# Altium schematic sheet Microcontroller.SchDoc(Microcontroller)
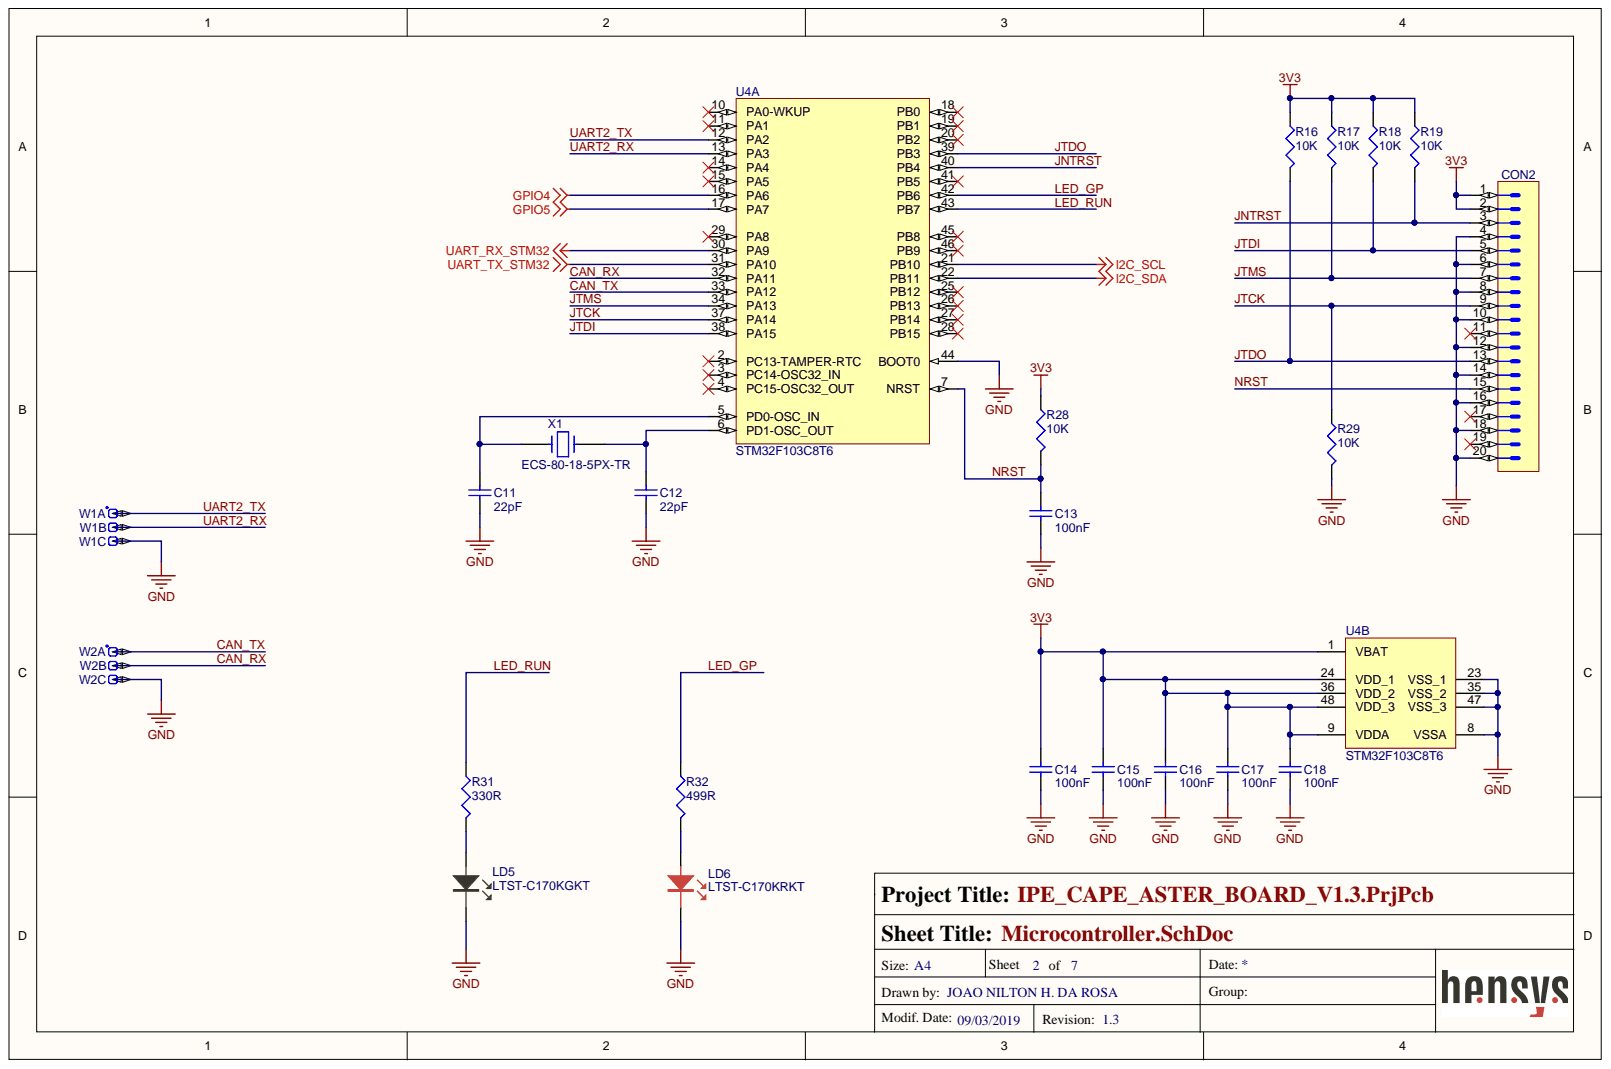
[diagram p0: Microcontroller.SchDoc]

## Parts
C11  22pF  [0402 cap]
C12  22pF  [0402 cap]
C13  100nF  [0402 cap]
C14  100nF  [0402 cap]
C15  100nF  [0402 cap]
C16  100nF  [0402 cap]
C17  100nF  [0402 cap]
C18  100nF  [0402 cap]
CON2  Header 20  [3M_N2520-6002RB]  (value not drawn)
LD5  LTST-C170KGKT  [0805_LED]
LD6  LTST-C170KRKT  [0805_LED]
R16  10K  [0402 res]
R17  10K  [0402 res]
R18  10K  [0402 res]
R19  10K  [0402 res]
R28  10K  [0402 res]
R29  10K  [0402 res]
R31  330R  [0603 res]
R32  499R  [0603 res]
U4  STM32F103C8T6  [STM-LQFP48_N]  (parts A,B)
W1  Test Point  [COMATEL_386.0358.1.03.440]  (value not drawn)  (parts A,B,C)
W2  Test Point  [COMATEL_386.0358.1.03.440]  (value not drawn)  (parts A,B,C)
X1  ECS-80-18-5PX-TR  [HC49/US]

## Nets
3V3: C14.2 C15.2 C16.2 C17.2 C18.2 CON2.1 CON2.2 R16.1 R17.1 R18.1 R19.1 R28.1 U4.1(VBAT) U4.9(VDDA) U4.24(VDD_1) U4.36(VDD_2) U4.48(VDD_3)
GND: C11.1 C12.1 C13.1 C14.1 C15.1 C16.1 C17.1 C18.1 CON2.4 CON2.6 CON2.8 CON2.10 CON2.12 CON2.14 CON2.16 CON2.18 CON2.20 LD5.1 LD6.1 R29.2 U4.8(VSSA) U4.23(VSS_1) U4.35(VSS_2) U4.44(BOOT0) U4.47(VSS_3) W1.3 W2.3
GPIO4: U4.16(PA6)
GPIO5: U4.17(PA7)
I2C_SCL: U4.21(PB10)
I2C_SDA: U4.22(PB11)
UART_RX_STM32: U4.30(PA9)
UART_TX_STM32: U4.31(PA10)
CAN_RX: U4.32(PA11) W2.2
CAN_TX: U4.33(PA12) W2.1
JNTRST: CON2.3 R19.2 U4.40(PB4)
JTCK: CON2.9 R29.1 U4.37(PA14)
JTDI: CON2.5 R18.2 U4.38(PA15)
JTDO: CON2.13 R16.2 U4.39(PB3)
JTMS: CON2.7 R17.2 U4.34(PA13)
LED_GP: R32.1 U4.42(PB6)
LED_RUN: R31.1 U4.43(PB7)
NRST: C13.2 CON2.15 R28.2 U4.7(NRST)
UART2_RX: U4.13(PA3) W1.2
UART2_TX: U4.12(PA2) W1.1
NetC11_2: C11.2 U4.5(PD0-OSC_IN) X1.1
NetC12_2: C12.2 U4.6(PD1-OSC_OUT) X1.2
NetLD5_2: LD5.2 R31.2
NetLD6_2: LD6.2 R32.2

Not connected: CON2 11 17 19; U4 2(PC13-TAMPER-RTC) 3(PC14-OSC32_IN) 4(PC15-OSC32_OUT) 10(PA0-WKUP) 11(PA1) 14(PA4) 15(PA5) 18(PB0) 19(PB1) 20(PB2) 25(PB12) 26(PB13) 27(PB14) 28(PB15) 29(PA8) 41(PB5) 45(PB8) 46(PB9)

## Library data of the parts
C11 C12 = Cap Ceram 0402: CAP CER 22PF 50V C0G/NP0 0402; Manufacturer Part Number: GRM1555C1H220FA01D; Manufacturer: Murata Electronics North America; Tolerance: ±1%; Temperature Coefficient: C0G, NP0; Notification: Current market demand for these product types have resulted in fluctuating and extending lead times. Lead times may differ.; Voltage - Rated: 50V; Thickness (Max): 0.022" (0.55mm); Supplier Part Number 1: 490-8589-1-ND; Supplier 1: Digi-Key; Stock 1: 485262; Size / Dimension: 0.039" L x 0.020" W (1.00mm x 0.50mm); RoHS: RoHS Compliant; Ratings: -; Pricing 1: 1=0.1, 10=0.05, 100=0.0223, 500=0.01594, 1000=0.01252, 2500=0.01138, 5000=0.01047 (USD); Part Status: Active; Packaging: Cut Tape (CT); Package / Case: 0402 (1005 Metric); Operating Temperature: -55°C ~ 125°C; Mounting Type: Surface Mount, MLCC; Lead Style: -; Lead Spacing: -; Height - Seated (Max): -; Features: -; ComponentLink1URL: http://search.murata.co.jp/Ceramy/image/img/A01X/G101/ENG/GRM1555C1H220FA01-01.pdf; ComponentLink1Description: http://search.murata.co.jp/Ceramy/image/img/A01X/G101/ENG/GRM1555C1H220FA01-01.pdf; Component Kind: Standard; Category: Capacitors; Applications: General Purpose; Library Name: Passivos.IntLib
C13-C18 = Cap Ceram 0402: CAP CER 0.1UF 16V X7R 0402; Manufacturer Part Number: GCM155R71C104KA55D; Manufacturer: Murata Electronics North America; Tolerance: ±10%; Voltage - Rated: 16V; Thickness (Max): 0.022" (0.55mm); Temperature Coefficient: X7R; Supplier Part Number 1: 490-4759-1-ND; Supplier 1: Digi-Key; Stock 1: 918618; Size / Dimension: 0.039" L x 0.020" W (1.00mm x 0.50mm); RoHS: RoHS Compliant; Ratings: AEC-Q200; Pricing 1: 1=0.1, 10=0.039, 100=0.0174, 500=0.01244, 1000=0.00977, 2500=0.00888, 5000=0.00817 (USD); Part Status: Active; Packaging: Cut Tape (CT); Package / Case: 0402 (1005 Metric); Operating Temperature: -55°C ~ 125°C; Mounting Type: Surface Mount, MLCC; Lead Style: -; Lead Spacing: -; Height - Seated (Max): -; Features: -; ComponentLink1URL: http://psearch.en.murata.com/capacitor/product/GCM155R71C104KA55#.pdf; ComponentLink1Description: http://psearch.en.murata.com/capacitor/product/GCM155R71C104KA55#.pdf; Component Kind: Standard; Category: Capacitors; Applications: Automotive; Library Name: Passivos.IntLib
CON2 = 3M_N2520-6002RB: Connector 20 Male; Part Description: 20 Contacts - Straight .100'' x .100'' Low Profile Four-Wall Header, 2500 Series; Table: 3M; Status: None; Socket: No; SMD: No; Pin Count: 20; Part Number: 3M_N2520-6002RB; Mounted: Yes; Manufacturer Part Number: N2520-6002RB; Manufacturer: 3M; Library Name: Connectors.DbLib; LatestRevisionDate: 11/08/2009; HelpURL: \\cern.ch\dfs\Applications\Altium\Datasheets\3M_N2520-6002RB.pdf; Family: Header 20; Database Table Name: 3M; CreateDate: 11/02/2009; Component Type: Standard; Component Kind: Standard; ComponentHeight: 10.03mm; Author: CERN DEM JLC
LD5 = LTST-C170KGKT: LED GREEN CLEAR 0805 SMD; Manufacturer: Lite-On Inc.; Wavelength - Peak: 574nm; Wavelength - Dominant: 571nm; Voltage - Forward (Vf) (Typ): 2V; Viewing Angle: 130°; Millicandela Rating: 35mcd; Lens Style/Size: Rectangle with Flat Top, 1.40mm x 1.25mm; Lens Color: Colorless; Current - Test: 20mA; Supplier Part Number 1: 160-1414-1-ND; Supplier 1: Digi-Key; Stock 1: 601256; Size / Dimension: 2.00mm L x 1.25mm W; RoHS: RoHS Compliant; Pricing 1: 1=0.38, 10=0.281, 25=0.2072, 100=0.148, 250=0.1006, 500=0.08878, 1000=0.06954 (USD); Part Status: Active; Packaging: Cut Tape (CT); Package / Case: 0805 (2012 Metric); Mounting Type: Surface Mount; Manufacturer Part Number: LTST-C170KGKT; Library Name: Leds.IntLib; Lens Transparency: Clear; Height (Max): 1.10mm; Features: -; ComponentLink1URL: http://optoelectronics.liteon.com/upload/download/DS22-2000-073/LTST-C170KGKT.pdf; ComponentLink1Description: http://optoelectronics.liteon.com/upload/download/DS22-2000-073/LTST-C170KGKT.pdf; Component Kind: Standard; Color: Green; Category: Optoelectronics
LD6 = LTST-C170KRKT: LED SUPER RED CLEAR 0805 SMD; Manufacturer: Lite-On Inc; Wavelength - Peak: 639nm; Wavelength - Dominant: 631nm; Voltage - Forward (Vf) (Typ): 2V; Viewing Angle: 130°; Millicandela Rating: 54mcd; Lens Style/Size: Rectangle with Flat Top, 1.4mm x 1.25mm; Current - Test: 20mA; Supplier Part Number 2: 160-1415-1-ND; Supplier Part Number 1: 160-1415-6-ND; Supplier 2: Digi-Key; Supplier 1: Digi-Key; Stock 2: 349195; Size / Dimension: 2.00mm L x 1.25mm W; RoHS: RoHS Compliant; Pricing 2: 1=0.37, 10=0.287, 100=0.1566, 250=0.1044, 500=0.08874, 1000=0.06786 (USD); Packaging: Cut Tape (CT); Package / Case: 0805 (2012 Metric); Mounting Type: Surface Mount; Manufacturer Part Number: LTST-C170KRKT; Luminous Flux @ Current - Test: -; Library Name: Leds.IntLib; Lens Type: Clear; Height: 1.10mm; ComponentLink1URL: http://optoelectronics.liteon.com/upload/download/DS-22-99-0150/S_110_LTST-C170KRKT.pdf; ComponentLink1Description: http://optoelectronics.liteon.com/upload/download/DS-22-99-0150/S_110_LTST-C170KRKT.pdf; Component Kind: Standard; Color: Red; Category: Optoelectronics
R16-R19 R28 R29 = Resistor 0402: RES SMD 10K OHM 5% 1/16W 0402; Manufacturer Part Number: RC1005J103CS; Manufacturer: Samsung Electro-Mechanics; Temperature Coefficient: ±100ppm/°C; Power (Watts): 0.063W, 1/16W; Tolerance: ±5%; Supplier Part Number 1: 1276-4400-1-ND; Supplier Device Package: 0402; Supplier 1: Digi-Key; Stock 1: 523260; Size / Dimension: 0.039" L x 0.020" W (1.00mm x 0.50mm); RoHS: RoHS Compliant; Pricing 1: 1=0.1, 10=0.011, 100=0.0046, 1000=0.00207, 2500=0.0018, 5000=0.00148 (USD); Part Status: Active; Packaging: Cut Tape (CT); Package / Case: 0402 (1005 Metric); Operating Temperature: -55°C ~ 155°C; Height - Seated (Max): 0.016" (0.40mm); Features: Moisture Resistant; Failure Rate: -; Composition: Thick Film; ComponentLink1URL: http://www.samsungsem.com/kr/support/product-search/chip-resistor/RC1005J103CS.jsp; ComponentLink1Description: http://www.samsungsem.com/kr/support/product-search/chip-resistor/RC1005J103CS.jsp; Component Kind: Standard; Category: Resistors; Library Name: Passivos.IntLib
R31 = Resistor 0603: RES SMD 330 OHM 1% 1/10W 0603; Manufacturer Part Number: RC1608F331CS; Manufacturer: Samsung Electro-Mechanics; Temperature Coefficient: ±100ppm/°C; Power (Watts): 0.1W, 1/10W; Tolerance: ±1%; Supplier Part Number 1: 1276-4604-6-ND; Supplier Device Package: 0603; Supplier 1: Digi-Key; Stock 1: 312119; Size / Dimension: 0.063" L x 0.032" W (1.60mm x 0.80mm); RoHS: RoHS Compliant; Pricing 1: 1=0.1, 10=0.025, 100=0.0101, 1000=0.00452, 2500=0.00392 (USD); Part Status: Active; Packaging: Digi-Reel®; Package / Case: 0603 (1608 Metric); Operating Temperature: -55°C ~ 155°C; Height - Seated (Max): 0.022" (0.55mm); Features: Moisture Resistant; Failure Rate: -; Composition: Thick Film; ComponentLink1URL: http://www.samsungsem.com/kr/support/product-search/chip-resistor/RC1608F331CS.jsp; ComponentLink1Description: http://www.samsungsem.com/kr/support/product-search/chip-resistor/RC1608F331CS.jsp; Component Kind: Standard; Category: Resistors; Library Name: Passivos.IntLib
R32 = Resistor 0603: RES SMD 499 OHM 1% 1/10W 0603; Manufacturer Part Number: RC1608F331CS; Manufacturer: Samsung Electro-Mechanics; Temperature Coefficient: ±100ppm/°C; Power (Watts): 0.1W, 1/10W; Tolerance: ±1%; Supplier Part Number 1: 1276-4604-6-ND; Supplier Device Package: 0603; Supplier 1: Digi-Key; Stock 1: 312119; Size / Dimension: 0.063" L x 0.032" W (1.60mm x 0.80mm); RoHS: RoHS Compliant; Pricing 1: 1=0.1, 10=0.025, 100=0.0101, 1000=0.00452, 2500=0.00392 (USD); Part Status: Active; Packaging: Digi-Reel®; Package / Case: 0603 (1608 Metric); Operating Temperature: -55°C ~ 155°C; Height - Seated (Max): 0.022" (0.55mm); Features: Moisture Resistant; Failure Rate: -; Composition: Thick Film; ComponentLink1URL: http://www.samsungsem.com/kr/support/product-search/chip-resistor/RC1608F331CS.jsp; ComponentLink1Description: http://www.samsungsem.com/kr/support/product-search/chip-resistor/RC1608F331CS.jsp; Component Kind: Standard; Category: Resistors; Library Name: Passivos.IntLib
U4 = STM32F103C8T6: ARM Cortex-M3 32-bit MCU, 64 KB Flash, 20 KB Internal RAM, 37 I/Os, 48-pin LQFP, -40 to 85 degC, Tray; Supply Voltage(Vcc) min (V): 2; Operating Frequency(F) (Processor speed) (MHz): 72; Internal RAM Size (kB): 20; I/Os (High Current): 37; FLASH Size (Prog) (kB): 64; A/D Converter: 2x12-bit (10 Channel); Supplier Part Number 5: 511-STM32F103C8T6; Supplier Part Number 4: 57P1222; Supplier Part Number 3: 59M2182; Supplier Part Number 2: 1447637; Supplier Part Number 1: 497-6063-ND; Supplier 5: Mouser; Supplier 4: Newark; Supplier 3: Newark; Supplier 2: Farnell; Supplier 1: Digi-Key; PartNumber: STM32F103C8T6; Packing: Tray; PackageVersion: Rev. 6, 06/2013; PackageReference: LQFP48; PackageDescription: 48-Pin Low Profile Quad Flat Package, 7 x 7 mm Body, 0.5 mm Pitch; Mounting Technology: Surface Mount; Library Name: STMicroelectronics STM32 F1.IntLib; DatasheetVersion: Rev. 16; Core: ARM Cortex-M3; ComponentLink3URL: http://www.st.com/st-web-ui/static/active/en/resource/technical/document/datasheet/DM00058181.pdf; ComponentLink3Description: Package Specification; ComponentLink2URL: http://www.st.com/st-web-ui/static/active/en/resource/technical/document/datasheet/CD00161566.pdf; ComponentLink2Description: Datasheet; ComponentLink1URL: http://www.st.com/web/en/home.html; ComponentLink1Description: Manufacturer URL; Component Kind: Standard
W1 W2 = COMATEL_386.0358.1.03.440: 3 Mini Wire-Wrap Contact Strip Header; Part Description: 3 Contacts Straight Strip Header Pitch 2.54mm L=6.3mm ''Mini-Wire-Wrap''; Manufacturer: KONTEK COMATEL; Table: STELVIO-KONTEK COMATEL; Status: Obsolete; Socket: No; SMD: No; Pin Count: 3; Part Number: COMATEL_386.0358.1.03.440; Mounted: Yes; Manufacturer Part Number: 386.0358.1.03.440; Library Name: Connectors.DbLib; LatestRevisionDate: 30/06/2010; HelpURL: \\cern.ch\dfs\Applications\Altium\Datasheets\COMATEL_386.0358.1.03.440.pdf; Family: Test Point; Database Table Name: STELVIO-KONTEK COMATEL; CreateDate: 29/08/2013; Component Type: Standard; Component Kind: Standard; ComponentHeight: 8mm; Author: CERN DEM JLC
X1 = ECS-80-18-5PX-TR: CRYSTAL 8MHZ 18PF SMD; Part Number: ABLS-8.000MHZ-B2-T; Manufacturer: ABRACON; Operating Mode: Fundamental; Frequency Tolerance: ±30ppm; Frequency Stability: ±50ppm; ESR (Equivalent Series Resistance): 60 Ohm; Type: MHz Crystal; Supplier Part Number 1: 535-9089-1-ND; Supplier 1: Digi-Key; Stock 1: 9748; Size / Dimension: 0.449" L x 0.189" W (11.40mm x 4.80mm); RoHS: RoHS Compliant; Ratings: -; Pricing 1: 1=0.47, 10=0.395, 50=0.354, 100=0.315, 500=0.3 (USD); Packaging: Cut Tape (CT); Package / Case: HC49/US; Operating Temperature: -10°C ~ 70°C; Mounting Type: Surface Mount; Manufacturer Part Number: ABLS-8.000MHZ-B2-T; Load Capacitance: 18pF; Library Name: LOAD_CELL_INTERFACE_V1.0.IntLib; Height: 0.169" (4.30mm); Frequency: 8MHz; ComponentLink2URL: http://www.abracon.com/Resonators/abls.pdf; ComponentLink2Description: http://www.abracon.com/Resonators/abls.pdf; ComponentLink1URL: http://www.ecsxtal.com/store/pdf/csm-7x.pdf; ComponentLink1Description: http://www.ecsxtal.com/store/pdf/csm-7x.pdf; Component Kind: Standard; Category: Crystals and Oscillators
